# Altium schematic sheet PowerSensor.SchDoc(PowerSensor)
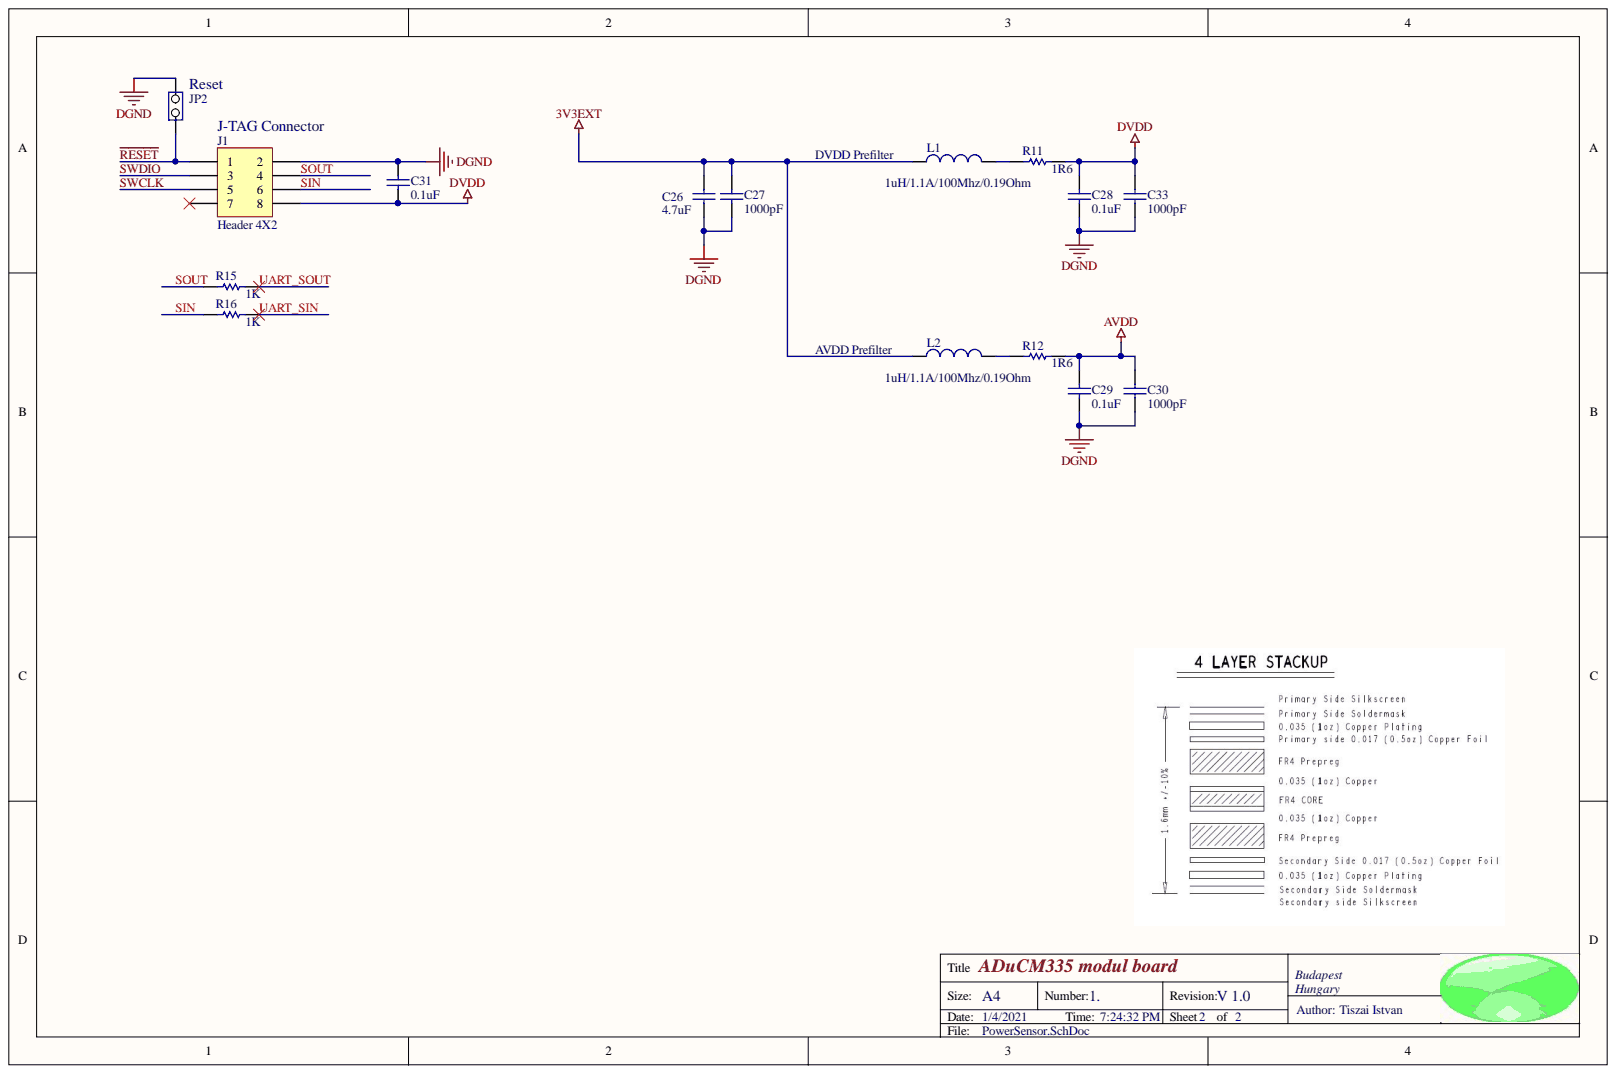
[diagram p0: PowerSensor.SchDoc]

## Parts
C26  4.7uF  [0402]
C27  1000pF  [0402 _S]
C28  0.1uF  [0402]
C29  0.1uF  [0402 _S]
C30  1000pF  [0402]
C31  0.1uF  [0402 _S]
C33  1000pF  [0402]
J1  Header 4X2  [MHDR2X4_S]
JP2  (no value)  [MHDR1X2_S]  (no BOM)
L1  1uH/1.1A/100Mhz/0.19Ohm  [0805]
L2  1uH/1.1A/100Mhz/0.19Ohm  [0805]
R11  1R6  [0402]
R12  1R6  [0402]
R15  1K  [0402 _S]
R16  1K  [0402]

## Nets
3V3EXT: C26.2 C27.2 L1.1 L2.1
AVDD: C29.2 C30.2 R12.1
DGND: C26.1 C27.1 C28.1 C29.1 C30.1 C31.2 C33.1 J1.2 JP2.2
DVDD: C28.2 C31.1 C33.2 J1.8 R11.1
~{RESET}: J1.1 JP2.1
SIN: J1.6 R16.2
SOUT: J1.4 R15.2
SWCLK: J1.5
SWDIO: J1.3
UART_SIN: R16.1
UART_SOUT: R15.1
NetL1_2: L1.2 R11.2
NetL2_2: L2.2 R12.2

Not connected: J1 7

## Library data of the parts
C26 = CAP SMD 0603  X5R 1µF 25V 10%: 4.7µF, 0402 C1005X5R0J475K050BC; Value: 4.7µF; Supplier Part Number 1: 2346876; Supplier 1: Farnell; Library Name: MB963_1.SCHLIB; Component Kind: Standard
C27 = Cap: Capacitor, 50V, 1%,, 0402, GRM1555C1H102FA01D; Value: 1000pF; Supplier Part Number 1: 2470452; Supplier 1: Farnell; Revision: July-2002: Re-released for DXP Platform.; Library Name: Miscellaneous Devices.IntLib; Component Kind: Standard
C28 C29 = Cap: Capacitor, 6.3V,,X7R, 10%, 04023C104KAT2A; Value: 0.1uF; Supplier Part Number 1: 1867948; Supplier 1: Farnell; Revision: July-2002: Re-released for DXP Platform.; Library Name: Miscellaneous Devices.IntLib; Component Kind: Standard
C30 C33 = CAP SMD 0603  X5R 1µF 25V 10%: Capacitor, 50V, 1%,, 0402, GRM1555C1H102FA01D; Value: 1000pF; Supplier Part Number 1: 2470452; Supplier 1: Farnell; Library Name: MB963_1.SCHLIB; Component Kind: Standard
C31 = Cap: Capacitor, 6.3V,,X5R, 10%, MC0402X104K6R3CT; Value: 0.1uF; Supplier Part Number 1: 1657913; Supplier 1: Farnell; Revision: July-2002: Re-released for DXP Platform.; Library Name: Miscellaneous Devices.IntLib; Component Kind: Standard
J1 = Header 4X2: Header, 4-Pin, Dual row,62200821121; Supplier Part Number 1: 2356244; Supplier 1: Farnell; Signal Integrity: Connector; Library Name: Miscellaneous Connectors.IntLib; Component Kind: Standard
JP2 = JP2: 1.27 1 row M52-040023V0545; Supplier Part Number 1: 1099560; Supplier 1: Farnell
L1 L2 = Inductor: 1uH/1.1A,74479774210,Wurth,0805; Value: 1uH; Supplier Part Number 1: 2114310; Supplier 1: Farnell; Revision: July-2002: Re-released for DXP Platform.; Library Name: Miscellaneous Devices.IntLib; Component Kind: Standard
R11 R12 = Resistor_ST: Resistor, 5%  ERJ2GEJ1R6X 0402; Value: 1R6; Supplier Part Number 1: 2324282; Supplier 1: Farnell; Library Name: Miscellaneous Devices.IntLib; Component Kind: Standard
R15 R16 = Resistor_ST: Resistor, 1%  CRCW06031K00FKEA; Value: 1K; Supplier Part Number 1: 1469740; Supplier 1: Farnell; Library Name: Miscellaneous Devices.IntLib; Component Kind: Standard
